# Altium schematic sheet MPU_12x12.SchDoc(U_MPU_12x12)
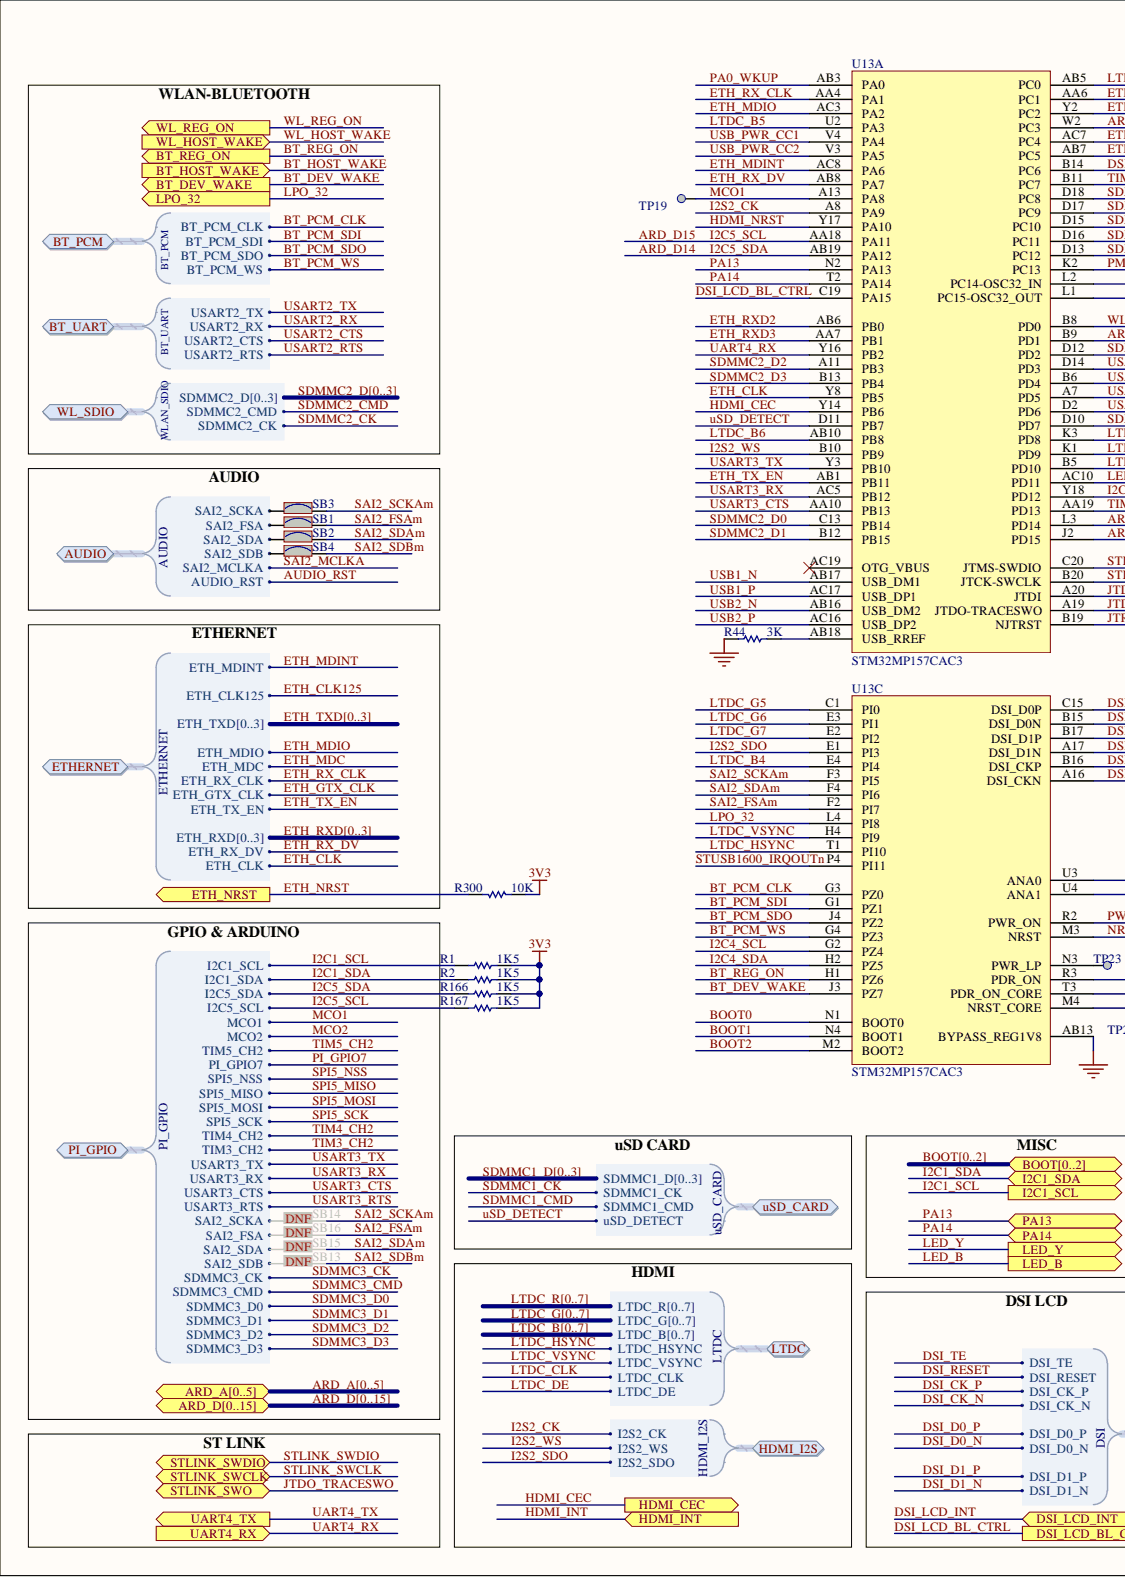
[diagram p0: MPU_12x12.SchDoc, left]
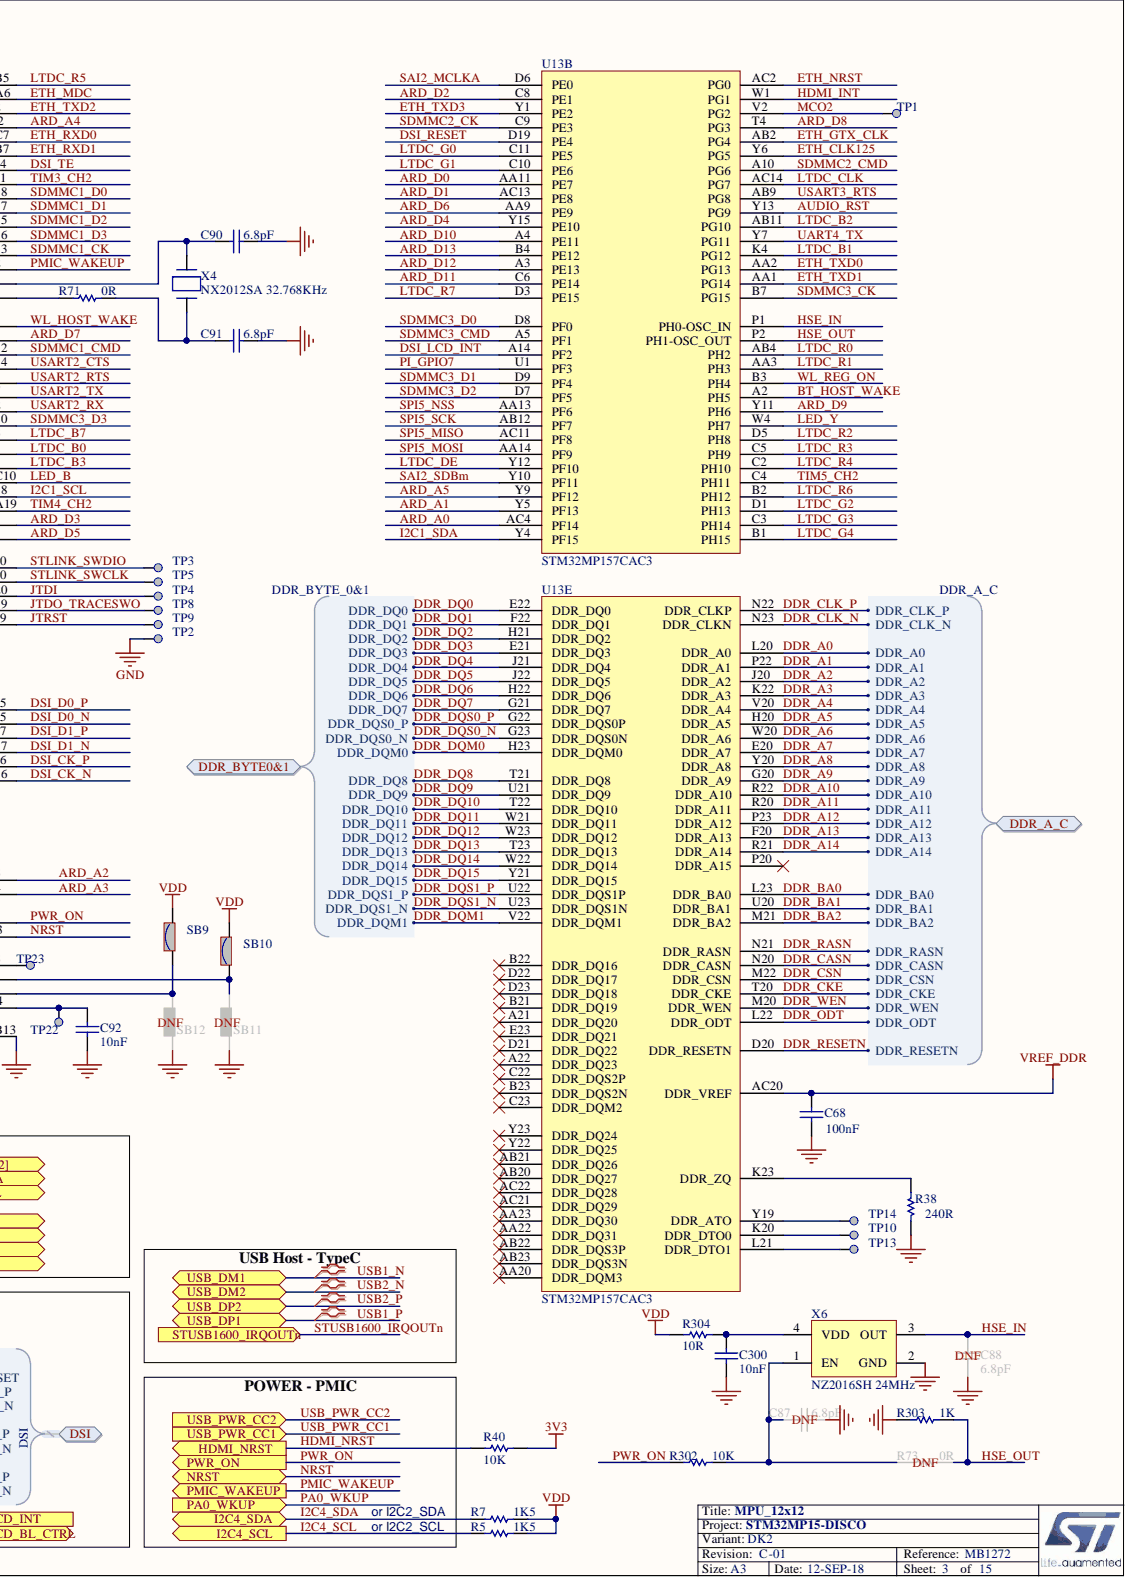
[diagram p1: MPU_12x12.SchDoc, right]

## Parts
C68  value 100nF  [C0402]
C87  value 6.8pF  [C0402]  (hidden comment: Capacitor)
C88  value 6.8pF  [C0402]  (hidden comment: Capacitor)
C90  value 6.8pF  [C0402]  (hidden comment: Capacitor)
C91  value 6.8pF  [C0402]  (hidden comment: Capacitor)
C92  value 10nF  [C0402]  (hidden comment: Capacitor)
C300  value 10nF  [C0402]  (hidden comment: Capacitor)
R1  value 1K5  [R0402]
R2  value 1K5  [R0402]
R5  value 1K5  [R0402]
R7  value 1K5  [R0402]
R38  value 240R  [R0402]
R40  value 10K  [R0402]  (hidden comment: Resistor)
R44  value 3K  [R0402]
R71  value 0R  [R0402]
R73  value 0R  [R0402]
R166  value 1K5  [R0402]
R167  value 1K5  [R0402]
R300  value 10K  [R0402]  (hidden comment: Resistor)
R302  value 10K  [R0402]  (hidden comment: Resistor)
R303  value 1K  [R0402]
R304  value 10R  [R0402]  (hidden comment: Resistor)
SB1  (no value)  [SOLDER-BRIDGE_R0603-0R]
SB2  (no value)  [SOLDER-BRIDGE_R0603-0R]
SB3  (no value)  [SOLDER-BRIDGE_R0603-0R]
SB4  (no value)  [SOLDER-BRIDGE_R0603-0R]
SB9  (no value)  [SOLDER-BRIDGE_R0603-0R]
SB10  (no value)  [SOLDER-BRIDGE_R0603-0R]
SB11  (no value)  [SOLDER-BRIDGE_R0603-0R]
SB12  (no value)  [SOLDER-BRIDGE_R0603-0R]
SB13  (no value)  [SOLDER-BRIDGE_R0603-0R]
SB14  (no value)  [SOLDER-BRIDGE_R0603-0R]
SB15  (no value)  [SOLDER-BRIDGE_R0603-0R]
SB16  (no value)  [SOLDER-BRIDGE_R0603-0R]
TP1  Test Point  [TP_SMD_1MM]  (value not drawn)  (no BOM)
TP2  Test Point  [TP_SMD_1MM]  (value not drawn)  (no BOM)
TP3  Test Point  [TP_SMD_1MM]  (value not drawn)  (no BOM)
TP4  Test Point  [TP_SMD_1MM]  (value not drawn)  (no BOM)
TP5  Test Point  [TP_SMD_1MM]  (value not drawn)  (no BOM)
TP8  Test Point  [TP_SMD_1MM]  (value not drawn)  (no BOM)
TP9  Test Point  [TP_SMD_1MM]  (value not drawn)  (no BOM)
TP10  (no value)  [TP_SMD_0-6MM]
TP13  (no value)  [TP_SMD_0-6MM]
TP14  (no value)  [TP_SMD_0-6MM]
TP19  Test Point  [TP_SMD_1MM]  (value not drawn)  (no BOM)
TP22  Test Point  [TP_SMD_1MM]  (value not drawn)  (no BOM)
TP23  Test Point  [TP_SMD_1MM]  (value not drawn)  (no BOM)
U13  STM32MP157CAC3  [ICC_TFBGA361_12X12_STM32MP157CAC3_P050-065]  (parts A,B,C,E)
U13  STM32MP157AAC3  [ICC_TFBGA361_12X12_STM32MP157CAC3_P050-065]  (value not drawn)  (part A)
U13  STM32MP157AAC3  [ICC_TFBGA361_12X12_STM32MP157CAC3_P050-065]  (value not drawn)  (part B)
U13  STM32MP157AAC3  [ICC_TFBGA361_12X12_STM32MP157CAC3_P050-065]  (value not drawn)  (part C)
U13  STM32MP157AAC3  [ICC_TFBGA361_12X12_STM32MP157CAC3_P050-065]  (value not drawn)  (part E)
X4  value NX2012SA 32.768KHz  Package: NX2012SA  [CRY_NX2012SA_2P]  (hidden comment: XTAL_2_PINS)
X6  value NZ2016SH 24MHz  [CRY_NZ2016SH_4P]  (hidden comment: OSCILLATOR_4_PINS)

## Ports
ARD_A0, ARD_A1, ARD_A2, ARD_A3, ARD_A4, ARD_A5, ARD_A[0..5], ARD_D0, ARD_D1, ARD_D2, ARD_D3, ARD_D4, ARD_D5, ARD_D6, ARD_D7, ARD_D8, ARD_D9, ARD_D10, ARD_D11, ARD_D12, ARD_D13, ARD_D14, ARD_D15, ARD_D[0..15], AUDIO, AUDIO.AUDIO_RST, AUDIO.SAI2_FSA, AUDIO.SAI2_MCLKA, AUDIO.SAI2_SCKA, AUDIO.SAI2_SDA, AUDIO.SAI2_SDB, BOOT0, BOOT1, BOOT2, BOOT[0..2], BT_DEV_WAKE, BT_HOST_WAKE, BT_PCM, BT_PCM.BT_PCM_CLK, BT_PCM.BT_PCM_SDI, BT_PCM.BT_PCM_SDO, BT_PCM.BT_PCM_WS, BT_REG_ON, BT_UART, BT_UART.USART2_CTS, BT_UART.USART2_RTS, BT_UART.USART2_RX, BT_UART.USART2_TX, DDR_A_C, DDR_A_C.DDR_A0, DDR_A_C.DDR_A1, DDR_A_C.DDR_A2, DDR_A_C.DDR_A3, DDR_A_C.DDR_A4, DDR_A_C.DDR_A5, DDR_A_C.DDR_A6, DDR_A_C.DDR_A7, DDR_A_C.DDR_A8, DDR_A_C.DDR_A9, DDR_A_C.DDR_A10, DDR_A_C.DDR_A11, DDR_A_C.DDR_A12, DDR_A_C.DDR_A13, DDR_A_C.DDR_A14, DDR_A_C.DDR_BA0, DDR_A_C.DDR_BA1, DDR_A_C.DDR_BA2, DDR_A_C.DDR_CASN, DDR_A_C.DDR_CKE, DDR_A_C.DDR_CLK_N, DDR_A_C.DDR_CLK_P, DDR_A_C.DDR_CSN, DDR_A_C.DDR_ODT, DDR_A_C.DDR_RASN, DDR_A_C.DDR_RESETN, DDR_A_C.DDR_WEN, DDR_BYTE0&1, DDR_BYTE0&1.DDR_DQ0, DDR_BYTE0&1.DDR_DQ1, DDR_BYTE0&1.DDR_DQ2, DDR_BYTE0&1.DDR_DQ3, DDR_BYTE0&1.DDR_DQ4, DDR_BYTE0&1.DDR_DQ5, DDR_BYTE0&1.DDR_DQ6, DDR_BYTE0&1.DDR_DQ7, DDR_BYTE0&1.DDR_DQ8, DDR_BYTE0&1.DDR_DQ9, DDR_BYTE0&1.DDR_DQ10, DDR_BYTE0&1.DDR_DQ11, DDR_BYTE0&1.DDR_DQ12, DDR_BYTE0&1.DDR_DQ13, DDR_BYTE0&1.DDR_DQ14, DDR_BYTE0&1.DDR_DQ15, DDR_BYTE0&1.DDR_DQM0, DDR_BYTE0&1.DDR_DQM1, DDR_BYTE0&1.DDR_DQS0_N, DDR_BYTE0&1.DDR_DQS0_P, DDR_BYTE0&1.DDR_DQS1_N, DDR_BYTE0&1.DDR_DQS1_P, DSI, DSI.DSI_CK_N, DSI.DSI_CK_P, DSI.DSI_D0_N, DSI.DSI_D0_P, DSI.DSI_D1_N, DSI.DSI_D1_P, DSI.DSI_RESET, DSI.DSI_TE, DSI_LCD_BL_CTRL, DSI_LCD_INT, ETH_NRST, ETHERNET, ETHERNET.ETH_CLK, ETHERNET.ETH_CLK125, ETHERNET.ETH_GTX_CLK, ETHERNET.ETH_MDC, ETHERNET.ETH_MDINT, ETHERNET.ETH_MDIO, ETHERNET.ETH_RX_CLK, ETHERNET.ETH_RX_DV, ETHERNET.ETH_RXD0, ETHERNET.ETH_RXD1, ETHERNET.ETH_RXD2, ETHERNET.ETH_RXD3, ETHERNET.ETH_RXD[0..3], ETHERNET.ETH_TX_EN, ETHERNET.ETH_TXD0, ETHERNET.ETH_TXD1, ETHERNET.ETH_TXD2, ETHERNET.ETH_TXD3, ETHERNET.ETH_TXD[0..3], HDMI_CEC, HDMI_I2S, HDMI_I2S.I2S2_CK, HDMI_I2S.I2S2_SDO, HDMI_I2S.I2S2_WS, HDMI_INT, HDMI_NRST, I2C1_SCL, I2C1_SDA, I2C4_SCL, I2C4_SDA, LED_B, LED_Y, LPO_32, LTDC, LTDC.LTDC_B0, LTDC.LTDC_B1, LTDC.LTDC_B2, LTDC.LTDC_B3, LTDC.LTDC_B4, LTDC.LTDC_B5, LTDC.LTDC_B6, LTDC.LTDC_B7, LTDC.LTDC_B[0..7], LTDC.LTDC_CLK, LTDC.LTDC_DE, LTDC.LTDC_G0, LTDC.LTDC_G1, LTDC.LTDC_G2, LTDC.LTDC_G3, LTDC.LTDC_G4, LTDC.LTDC_G5, LTDC.LTDC_G6, LTDC.LTDC_G7, LTDC.LTDC_G[0..7], LTDC.LTDC_HSYNC, LTDC.LTDC_R0, LTDC.LTDC_R1, LTDC.LTDC_R2, LTDC.LTDC_R3, LTDC.LTDC_R4, LTDC.LTDC_R5, LTDC.LTDC_R6, LTDC.LTDC_R7, LTDC.LTDC_R[0..7], LTDC.LTDC_VSYNC, NRST, PA0_WKUP, PA13, PA14, PI_GPIO, PI_GPIO.I2C1_SCL, PI_GPIO.I2C1_SDA, PI_GPIO.I2C5_SCL, PI_GPIO.I2C5_SDA, PI_GPIO.MCO1, PI_GPIO.MCO2, PI_GPIO.PI_GPIO7, PI_GPIO.SAI2_FSA, PI_GPIO.SAI2_SCKA, PI_GPIO.SAI2_SDA, PI_GPIO.SAI2_SDB, PI_GPIO.SDMMC3_CK, PI_GPIO.SDMMC3_CMD, PI_GPIO.SDMMC3_D0, PI_GPIO.SDMMC3_D1, PI_GPIO.SDMMC3_D2, PI_GPIO.SDMMC3_D3, PI_GPIO.SPI5_MISO, PI_GPIO.SPI5_MOSI, PI_GPIO.SPI5_NSS, PI_GPIO.SPI5_SCK, PI_GPIO.TIM3_CH2, PI_GPIO.TIM4_CH2, PI_GPIO.TIM5_CH2, PI_GPIO.USART3_CTS, PI_GPIO.USART3_RTS, PI_GPIO.USART3_RX, PI_GPIO.USART3_TX, PMIC_WAKEUP, PWR_ON, STLINK_SWCLK, STLINK_SWDIO, STLINK_SWO, STUSB1600_IRQOUTn, UART4_RX, UART4_TX, USB_DM1, USB_DM2, USB_DP1, USB_DP2, USB_PWR_CC1, USB_PWR_CC2, uSD_CARD, uSD_CARD.SDMMC1_CK, uSD_CARD.SDMMC1_CMD, USD_CARD.SDMMC1_D0, USD_CARD.SDMMC1_D1, USD_CARD.SDMMC1_D2, USD_CARD.SDMMC1_D3, uSD_CARD.SDMMC1_D[0..3], uSD_CARD.uSD_DETECT, WL_HOST_WAKE, WL_REG_ON, WL_SDIO, WL_SDIO.SDMMC2_CK, WL_SDIO.SDMMC2_CMD, WL_SDIO.SDMMC2_D0, WL_SDIO.SDMMC2_D1, WL_SDIO.SDMMC2_D2, WL_SDIO.SDMMC2_D3, WL_SDIO.SDMMC2_D[0..3]

## Nets
3V3: R1.1 R2.1 R40.1 R166.1 R167.1 R300.1
GND: C68.1 C87.2 C88.1 C90.2 C91.2 C92.1 C300.1 R38.2 R44.1 R303.2 SB11.1 SB12.1 TP2.1 U13.AB13 X6.2(GND)
VDD: R5.1 R7.1 R304.1 SB9.2 SB10.2
VREF_DDR: C68.2 U13.AC20
ARD_A0: U13.AC4 ; port ARD_A[0..5]  [also labelled ARD_A]
ARD_A1: U13.Y5 ; port ARD_A[0..5]  [also labelled ARD_A]
ARD_A2: U13.U3 ; port ARD_A[0..5]  [also labelled ARD_A]
ARD_A3: U13.U4 ; port ARD_A[0..5]  [also labelled ARD_A]
ARD_A4: U13.W2 ; port ARD_A[0..5]  [also labelled ARD_A]
ARD_A5: U13.Y9 ; port ARD_A[0..5]  [also labelled ARD_A]
ARD_D0: U13.AA11 ; port ARD_D[0..15]  [also labelled ARD_D]
ARD_D1: U13.AC13 ; port ARD_D[0..15]  [also labelled ARD_D]
ARD_D2: U13.C8 ; port ARD_D[0..15]  [also labelled ARD_D]
ARD_D3: U13.L3 ; port ARD_D[0..15]  [also labelled ARD_D]
ARD_D4: U13.Y15 ; port ARD_D[0..15]  [also labelled ARD_D]
ARD_D5: U13.J2 ; port ARD_D[0..15]  [also labelled ARD_D]
ARD_D6: U13.AA9 ; port ARD_D[0..15]  [also labelled ARD_D]
ARD_D7: U13.B9 ; port ARD_D[0..15]  [also labelled ARD_D]
ARD_D8: U13.T4 ; port ARD_D[0..15]  [also labelled ARD_D]
ARD_D9: U13.Y11 ; port ARD_D[0..15]  [also labelled ARD_D]
ARD_D10: U13.A4 ; port ARD_D[0..15]  [also labelled ARD_D]
ARD_D11: U13.C6 ; port ARD_D[0..15]  [also labelled ARD_D]
ARD_D12: U13.A3 ; port ARD_D[0..15]  [also labelled ARD_D]
ARD_D13: U13.B4 ; port ARD_D[0..15]  [also labelled ARD_D]
ARD_D14: R166.2 U13.AB19 ; port ARD_D[0..15], port PI_GPIO  [also labelled ARD_D]
ARD_D15: R167.2 U13.AA18 ; port ARD_D[0..15], port PI_GPIO  [also labelled ARD_D]
AUDIO_RST: U13.Y13 ; port AUDIO
BOOT0: U13.N1 ; port BOOT[0..2]  [also labelled BOOT]
BOOT1: U13.N4 ; port BOOT[0..2]  [also labelled BOOT]
BOOT2: U13.M2 ; port BOOT[0..2]  [also labelled BOOT]
BT_DEV_WAKE: U13.J3 ; port BT_DEV_WAKE
BT_HOST_WAKE: U13.A2 ; port BT_HOST_WAKE
BT_PCM_CLK: U13.G3 ; port BT_PCM
BT_PCM_SDI: U13.G1 ; port BT_PCM
BT_PCM_SDO: U13.J4 ; port BT_PCM
BT_PCM_WS: U13.G4 ; port BT_PCM
BT_REG_ON: U13.H1 ; port BT_REG_ON
DDR_A0: U13.L20 ; port DDR_A_C
DDR_A1: U13.P22 ; port DDR_A_C
DDR_A2: U13.J20 ; port DDR_A_C
DDR_A3: U13.K22 ; port DDR_A_C
DDR_A4: U13.V20 ; port DDR_A_C
DDR_A5: U13.H20 ; port DDR_A_C
DDR_A6: U13.W20 ; port DDR_A_C
DDR_A7: U13.E20 ; port DDR_A_C
DDR_A8: U13.Y20 ; port DDR_A_C
DDR_A9: U13.G20 ; port DDR_A_C
DDR_A10: U13.R22 ; port DDR_A_C
DDR_A11: U13.R20 ; port DDR_A_C
DDR_A12: U13.P23 ; port DDR_A_C
DDR_A13: U13.F20 ; port DDR_A_C
DDR_A14: U13.R21 ; port DDR_A_C
DDR_BA0: U13.L23 ; port DDR_A_C
DDR_BA1: U13.U20 ; port DDR_A_C
DDR_BA2: U13.M21 ; port DDR_A_C
DDR_CASN: U13.N20 ; port DDR_A_C
DDR_CKE: U13.T20 ; port DDR_A_C
DDR_CLK_N: U13.N23 ; port DDR_A_C
DDR_CLK_P: U13.N22 ; port DDR_A_C
DDR_CSN: U13.M22 ; port DDR_A_C
DDR_DQ0: U13.E22 ; port DDR_BYTE0&1
DDR_DQ1: U13.F22 ; port DDR_BYTE0&1
DDR_DQ2: U13.H21 ; port DDR_BYTE0&1
DDR_DQ3: U13.E21 ; port DDR_BYTE0&1
DDR_DQ4: U13.J21 ; port DDR_BYTE0&1
DDR_DQ5: U13.J22 ; port DDR_BYTE0&1
DDR_DQ6: U13.H22 ; port DDR_BYTE0&1
DDR_DQ7: U13.G21 ; port DDR_BYTE0&1
DDR_DQ8: U13.T21 ; port DDR_BYTE0&1
DDR_DQ9: U13.U21 ; port DDR_BYTE0&1
DDR_DQ10: U13.T22 ; port DDR_BYTE0&1
DDR_DQ11: U13.W21 ; port DDR_BYTE0&1
DDR_DQ12: U13.W23 ; port DDR_BYTE0&1
DDR_DQ13: U13.T23 ; port DDR_BYTE0&1
DDR_DQ14: U13.W22 ; port DDR_BYTE0&1
DDR_DQ15: U13.Y21 ; port DDR_BYTE0&1
DDR_DQM0: U13.H23 ; port DDR_BYTE0&1
DDR_DQM1: U13.V22 ; port DDR_BYTE0&1
DDR_DQS0_N: U13.G23 ; port DDR_BYTE0&1
DDR_DQS0_P: U13.G22 ; port DDR_BYTE0&1
DDR_DQS1_N: U13.U23 ; port DDR_BYTE0&1
DDR_DQS1_P: U13.U22 ; port DDR_BYTE0&1
DDR_ODT: U13.L22 ; port DDR_A_C
DDR_RASN: U13.N21 ; port DDR_A_C
DDR_RESETN: U13.D20 ; port DDR_A_C
DDR_WEN: U13.M20 ; port DDR_A_C
DSI_CK_N: U13.A16 ; port DSI
DSI_CK_P: U13.B16 ; port DSI
DSI_D0_N: U13.B15 ; port DSI
DSI_D0_P: U13.C15 ; port DSI
DSI_D1_N: U13.A17 ; port DSI
DSI_D1_P: U13.B17 ; port DSI
DSI_LCD_BL_CTRL: U13.C19 ; port DSI_LCD_BL_CTRL
DSI_LCD_INT: U13.A14 ; port DSI_LCD_INT
DSI_RESET: U13.D19 ; port DSI
DSI_TE: U13.B14 ; port DSI
ETH_CLK: U13.Y8 ; port ETHERNET
ETH_CLK125: U13.Y6 ; port ETHERNET
ETH_GTX_CLK: U13.AB2 ; port ETHERNET
ETH_MDC: U13.AA6 ; port ETHERNET
ETH_MDINT: U13.AC8 ; port ETHERNET
ETH_MDIO: U13.AC3 ; port ETHERNET
ETH_NRST: R300.2 U13.AC2 ; port ETH_NRST
ETH_RX_CLK: U13.AA4 ; port ETHERNET
ETH_RX_DV: U13.AB8 ; port ETHERNET
ETH_RXD0: U13.AC7 ; port ETHERNET  [also labelled ETH_RXD]
ETH_RXD1: U13.AB7 ; port ETHERNET  [also labelled ETH_RXD]
ETH_RXD2: U13.AB6 ; port ETHERNET  [also labelled ETH_RXD]
ETH_RXD3: U13.AA7 ; port ETHERNET  [also labelled ETH_RXD]
ETH_TX_EN: U13.AB1 ; port ETHERNET
ETH_TXD0: U13.AA2 ; port ETHERNET  [also labelled ETH_TXD]
ETH_TXD1: U13.AA1 ; port ETHERNET  [also labelled ETH_TXD]
ETH_TXD2: U13.Y2 ; port ETHERNET  [also labelled ETH_TXD]
ETH_TXD3: U13.Y1 ; port ETHERNET  [also labelled ETH_TXD]
HDMI_CEC: U13.Y14 ; port HDMI_CEC
HDMI_INT: U13.W1 ; port HDMI_INT
HDMI_NRST: R40.2 U13.Y17 ; port HDMI_NRST
HSE_IN: C88.2 U13.P1 X6.3(OUT)
HSE_OUT: R73.2 R303.1 U13.P2
I2C1_SCL: R1.2 U13.Y18 ; port I2C1_SCL, port PI_GPIO
I2C1_SDA: R2.2 U13.Y4 ; port I2C1_SDA, port PI_GPIO
I2C4_SCL: R5.2 U13.G2 ; port I2C4_SCL
I2C4_SDA: R7.2 U13.H2 ; port I2C4_SDA
I2S2_CK: U13.A8 ; port HDMI_I2S
I2S2_SDO: U13.E1 ; port HDMI_I2S
I2S2_WS: U13.B10 ; port HDMI_I2S
JTDI: TP4.1 U13.A20
JTDO_TRACESWO: TP8.1 U13.A19 ; port STLINK_SWO
JTRST: TP9.1 U13.B19
LED_B: U13.AC10 ; port LED_B
LED_Y: U13.W4 ; port LED_Y
LPO_32: U13.L4 ; port LPO_32
LTDC_B0: U13.K1 ; port LTDC  [also labelled LTDC_B]
LTDC_B1: U13.K4 ; port LTDC  [also labelled LTDC_B]
LTDC_B2: U13.AB11 ; port LTDC  [also labelled LTDC_B]
LTDC_B3: U13.B5 ; port LTDC  [also labelled LTDC_B]
LTDC_B4: U13.E4 ; port LTDC  [also labelled LTDC_B]
LTDC_B5: U13.U2 ; port LTDC  [also labelled LTDC_B]
LTDC_B6: U13.AB10 ; port LTDC  [also labelled LTDC_B]
LTDC_B7: U13.K3 ; port LTDC  [also labelled LTDC_B]
LTDC_CLK: U13.AC14 ; port LTDC
LTDC_DE: U13.Y12 ; port LTDC
LTDC_G0: U13.C11 ; port LTDC  [also labelled LTDC_G]
LTDC_G1: U13.C10 ; port LTDC  [also labelled LTDC_G]
LTDC_G2: U13.D1 ; port LTDC  [also labelled LTDC_G]
LTDC_G3: U13.C3 ; port LTDC  [also labelled LTDC_G]
LTDC_G4: U13.B1 ; port LTDC  [also labelled LTDC_G]
LTDC_G5: U13.C1 ; port LTDC  [also labelled LTDC_G]
LTDC_G6: U13.E3 ; port LTDC  [also labelled LTDC_G]
LTDC_G7: U13.E2 ; port LTDC  [also labelled LTDC_G]
LTDC_HSYNC: U13.T1 ; port LTDC
LTDC_R0: U13.AB4 ; port LTDC  [also labelled LTDC_R]
LTDC_R1: U13.AA3 ; port LTDC  [also labelled LTDC_R]
LTDC_R2: U13.D5 ; port LTDC  [also labelled LTDC_R]
LTDC_R3: U13.C5 ; port LTDC  [also labelled LTDC_R]
LTDC_R4: U13.C2 ; port LTDC  [also labelled LTDC_R]
LTDC_R5: U13.AB5 ; port LTDC  [also labelled LTDC_R]
LTDC_R6: U13.B2 ; port LTDC  [also labelled LTDC_R]
LTDC_R7: U13.D3 ; port LTDC  [also labelled LTDC_R]
LTDC_VSYNC: U13.H4 ; port LTDC
MCO1: TP19.1 U13.A13 ; port PI_GPIO
MCO2: TP1.1 U13.V2 ; port PI_GPIO
NRST: U13.M3 ; port NRST
PA0_WKUP: U13.AB3 ; port PA0_WKUP
PA13: U13.N2 ; port PA13
PA14: U13.T2 ; port PA14
PI_GPIO7: U13.U1 ; port PI_GPIO
PMIC_WAKEUP: U13.K2 ; port PMIC_WAKEUP
PWR_ON: R302.2 U13.R2 ; port PWR_ON
SAI2_FSAm: SB1.2 SB16.2 U13.F2
SAI2_MCLKA: U13.D6 ; port AUDIO
SAI2_SCKAm: SB3.2 SB14.2 U13.F3
SAI2_SDAm: SB2.2 SB15.2 U13.F4
SAI2_SDBm: SB4.2 SB13.2 U13.Y10
SDMMC1_CK: U13.D13 ; port uSD_CARD
SDMMC1_CMD: U13.D12 ; port uSD_CARD
SDMMC1_D0: U13.D18 ; port uSD_CARD  [also labelled SDMMC1_D]
SDMMC1_D1: U13.D17 ; port uSD_CARD  [also labelled SDMMC1_D]
SDMMC1_D2: U13.D15 ; port uSD_CARD  [also labelled SDMMC1_D]
SDMMC1_D3: U13.D16 ; port uSD_CARD  [also labelled SDMMC1_D]
SDMMC2_CK: U13.C9 ; port WL_SDIO
SDMMC2_CMD: U13.A10 ; port WL_SDIO
SDMMC2_D0: U13.C13 ; port WL_SDIO  [also labelled SDMMC2_D]
SDMMC2_D1: U13.B12 ; port WL_SDIO  [also labelled SDMMC2_D]
SDMMC2_D2: U13.A11 ; port WL_SDIO  [also labelled SDMMC2_D]
SDMMC2_D3: U13.B13 ; port WL_SDIO  [also labelled SDMMC2_D]
SDMMC3_CK: U13.B7 ; port PI_GPIO
SDMMC3_CMD: U13.A5 ; port PI_GPIO
SDMMC3_D0: U13.D8 ; port PI_GPIO
SDMMC3_D1: U13.D9 ; port PI_GPIO
SDMMC3_D2: U13.D7 ; port PI_GPIO
SDMMC3_D3: U13.D10 ; port PI_GPIO
SPI5_MISO: U13.AC11 ; port PI_GPIO
SPI5_MOSI: U13.AA14 ; port PI_GPIO
SPI5_NSS: U13.AA13 ; port PI_GPIO
SPI5_SCK: U13.AB12 ; port PI_GPIO
STLINK_SWCLK: TP5.1 U13.B20 ; port STLINK_SWCLK
STLINK_SWDIO: TP3.1 U13.C20 ; port STLINK_SWDIO
STUSB1600_IRQOUTn: U13.P4 ; port STUSB1600_IRQOUTn
TIM3_CH2: U13.B11 ; port PI_GPIO
TIM4_CH2: U13.AA19 ; port PI_GPIO
TIM5_CH2: U13.C4 ; port PI_GPIO
UART4_RX: U13.Y16 ; port UART4_RX
UART4_TX: U13.Y7 ; port UART4_TX
USART2_CTS: U13.D14 ; port BT_UART
USART2_RTS: U13.B6 ; port BT_UART
USART2_RX: U13.D2 ; port BT_UART
USART2_TX: U13.A7 ; port BT_UART
USART3_CTS: U13.AA10 ; port PI_GPIO
USART3_RTS: U13.AB9 ; port PI_GPIO
USART3_RX: U13.AC5 ; port PI_GPIO
USART3_TX: U13.Y3 ; port PI_GPIO
USB1_N: U13.AB17 ; port USB_DM1
USB1_P: U13.AC17 ; port USB_DP1
USB2_N: U13.AB16 ; port USB_DM2
USB2_P: U13.AC16 ; port USB_DP2
USB_PWR_CC1: U13.V4 ; port USB_PWR_CC1
USB_PWR_CC2: U13.V3 ; port USB_PWR_CC2
uSD_DETECT: U13.D11 ; port uSD_CARD
WL_HOST_WAKE: U13.B8 ; port WL_HOST_WAKE
WL_REG_ON: U13.B3 ; port WL_REG_ON
NetC87_1: C87.1 R73.1 R302.1 X6.1(EN)
NetC90_1: C90.1 U13.L2 X4.2
NetC91_1: C91.1 R71.2 X4.1
NetC92_2: C92.2 TP22.1 U13.M4
NetC300_2: C300.2 R304.2 X6.4(VDD)
NetR38_1: R38.1 U13.K23
NetR44_2: R44.2 U13.AB18
NetR71_1: R71.1 U13.L1
NetSB1_1: SB1.1 ; port AUDIO
NetSB2_1: SB2.1 ; port AUDIO
NetSB3_1: SB3.1 ; port AUDIO
NetSB4_1: SB4.1 ; port AUDIO
NetSB9_1: SB9.1 SB12.2 U13.T3
NetSB10_1: SB10.1 SB11.2 U13.R3
NetSB13_1: SB13.1 ; port PI_GPIO
NetSB14_1: SB14.1 ; port PI_GPIO
NetSB15_1: SB15.1 ; port PI_GPIO
NetSB16_1: SB16.1 ; port PI_GPIO
NetTP10_1: TP10.1 U13.K20
NetTP13_1: TP13.1 U13.L21
NetTP14_1: TP14.1 U13.Y19
NetTP23_1: TP23.1 U13.N3

Not connected: U13 A21 A22 AA20 AA22 AA23 AB20 AB21 AB22 AB23 AC19 AC21 AC22 B21 B22 B23 C22 C23 D21 D22 D23 E23 P20 Y22 Y23

## Library data of the parts
C68 = MCD-00006: Capacitor,100nF,16V,X7R,10%,0402; Manufacturer_Part_Number: CC0402KRX7R7BB104; Manufacturer: YAGEO; Alternate_Manufacturer_Part_Number: GCM155R71C104KA55D; Alternate_Manufacturer: MURATA; Provided_By: SUBCO; Initial_Board_Reference: mb1274; Database Table Name: MCD_PARTS$; Status: Released; Part_Type: Capacitor; Package: C0402; Library Name: MCD_PARTS_database.DbLib; Internal_Part_Number: MCD-00006; ECCN_US: n/a; ECCN_EU: n/a; ComponentLink1URL: http://tunmcdsvn.tun.st.com/Component_Datasheet_Library/Trunk/Capacitors/Yageo_Multilayer_Capacitor_X7R_series_100pF_to_22uF; ComponentLink1Description: Datasheet; Component Kind: Standard
C87 C88 = MCD-00674: Capacitor, MLCC, 6.8pF, 50V, NPO, +-0.25pF, 0402, T=0.5mm; Manufacturer_Part_Number: CC0402CRNPO9BN6R8; Manufacturer: YAGEO; Provided_By: SUBCO; Initial_Board_Reference: MB1319; Database Table Name: MCD_PARTS$; Status: Released; Part_Type: Capacitor; Package: C0402; Library Name: MCD_PARTS_database.DbLib; Internal_Part_Number: MCD-00674; ECCN_US: n/a; ECCN_EU: n/a; ComponentLink1URL: http://tunmcdsvn.tun.st.com/Component_Datasheet_Library/Trunk/Capacitors/Yageo_Capacitor_NP0_16V-to-50V; ComponentLink1Description: Datasheet; Component Kind: Standard
C90 C91 = MCD-00674: Capacitor, MLCC, 6.8pF, 50V, NPO, +-0.25pF, 0402, T=0.5mm; Manufacturer_Part_Number: CC0402CRNPO9BN6R8; Manufacturer: YAGEO; Provided_By: SUBCO; Path: ws/MCD_ApplicationBoards/Lists/MCDPARTSdatabase; Item Type: Item; Initial_Board_Reference: MB1319; Database Table Name: MCD_PARTS$; Status: Released; Part_Type: Capacitor; Package: C0402; Library Name: MCD_PARTS_database.DbLib; Internal_Part_Number: MCD-00674; ComponentLink1URL: http://tunmcdsvn.tun.st.com/Component_Datasheet_Library/Trunk/Capacitors/Yageo_Capacitor_NP0_16V-to-50V; ComponentLink1Description: Datasheet; Component Kind: Standard
C92 C300 = MCD-00011: Capacitor, MLCC, 10nF, 16V, X7R, 10%, 0402, T=0.5mm; Manufacturer_Part_Number: CC0402KRX7R7BB103; Manufacturer: YAGEO; Provided_By: SUBCO; Initial_Board_Reference: MB1274; Database Table Name: MCD_PARTS$; Status: Released; Part_Type: Capacitor; Package: C0402; Library Name: MCD_PARTS_database.DbLib; Internal_Part_Number: MCD-00011; ECCN_US: n/a; ECCN_EU: n/a; ComponentLink1URL: http://tunmcdsvn.tun.st.com/Component_Datasheet_Library/Trunk/Capacitors/Yageo_Multilayer_Capacitor_X7R_series_100pF_to_22uF; ComponentLink1Description: Datasheet; Component Kind: Standard
R1 R2 R5 R7 R166 R167 = MCD-00068: Resistor,1K5,0402,1%,1/16W; Manufacturer_Part_Number: RC0402FR-071K5L; Manufacturer: YAGEO; Provided_By: SUBCO; Initial_Board_Reference: mb1274; Database Table Name: MCD_PARTS$; Status: Released; Part_Type: Resistor; Package: R0402; Library Name: MCD_PARTS_database.DbLib; Internal_Part_Number: MCD-00068; ECCN_US: n/a; ECCN_EU: n/a; ComponentLink1URL: http://tunmcdsvn.tun.st.com/Component_Datasheet_Library/Trunk/Resistors/Yageo_Resistor_RC0402-series; ComponentLink1Description: Datasheet; Component Kind: Standard
R38 = MCD-00120: Resistor,240R,0402,1%,1/16W; Manufacturer_Part_Number: RC0402FR-07240RL; Manufacturer: YAGEO; Provided_By: SUBCO; Initial_Board_Reference: mb1262; Database Table Name: MCD_PARTS$; Status: Released; Part_Type: Resistor; Package: R0402; Library Name: MCD_PARTS_database.DbLib; Internal_Part_Number: MCD-00120; ECCN_US: n/a; ECCN_EU: n/a; ComponentLink1URL: http://tunmcdsvn.tun.st.com/Component_Datasheet_Library/Trunk/Resistors/Yageo_Resistor_RC0402-series; ComponentLink1Description: Datasheet; Component Kind: Standard
R40 R300 R302 = MCD-00053: Resistor,10K,0402,1%,1/16W; Manufacturer_Part_Number: RC0402FR-0710KL; Manufacturer: YAGEO; Provided_By: SUBCO; Initial_Board_Reference: MB1274; Database Table Name: MCD_PARTS$; Status: Released; Part_Type: Resistor; Package: R0402; Library Name: MCD_PARTS_database.DbLib; Internal_Part_Number: MCD-00053; ECCN_US: n/a; ECCN_EU: n/a; ComponentLink1URL: http://tunmcdsvn.tun.st.com/Component_Datasheet_Library/Trunk/Resistors/Yageo_Resistor_RC0402-series; ComponentLink1Description: Datasheet; Component Kind: Standard
R44 = MCD-00185: Resistor,3K,0402,1%,1/16W; Manufacturer_Part_Number: RC0402FR-073KL; Manufacturer: YAGEO; Provided_By: SUBCO; Database Table Name: MCD_PARTS$; Status: Released; Part_Type: Resistor; Package: R0402; Library Name: MCD_PARTS_database.DbLib; Internal_Part_Number: MCD-00185; ECCN_US: n/a; ECCN_EU: n/a; ComponentLink1URL: http://tunmcdsvn.tun.st.com/Component_Datasheet_Library/Trunk/Resistors/Yageo_Resistor_RC0402-series; ComponentLink1Description: Datasheet; Component Kind: Standard
R71 R73 = MCD-00052: Resistor,0R,0402,5%,1/16W; Manufacturer_Part_Number: RC0402JR-070RL; Manufacturer: YAGEO; Provided_By: SUBCO; Initial_Board_Reference: mb1274; Database Table Name: MCD_PARTS$; Status: Released; Part_Type: Resistor; Package: R0402; Library Name: MCD_PARTS_database.DbLib; Internal_Part_Number: MCD-00052; ECCN_US: n/a; ECCN_EU: n/a; ComponentLink1URL: http://tunmcdsvn.tun.st.com/Component_Datasheet_Library/Trunk/Resistors/Yageo_Resistor_RC0402-series; ComponentLink1Description: Datasheet; Component Kind: Standard
R303 = MCD-00061: Resistor,1K,0402,1%,1/16W; Manufacturer_Part_Number: RC0402FR-071KL; Manufacturer: YAGEO; Provided_By: SUBCO; Initial_Board_Reference: mb1274; Database Table Name: MCD_PARTS$; Status: Released; Part_Type: Resistor; Package: R0402; Library Name: MCD_PARTS_database.DbLib; Internal_Part_Number: MCD-00061; ECCN_US: n/a; ECCN_EU: n/a; ComponentLink1URL: http://tunmcdsvn.tun.st.com/Component_Datasheet_Library/Trunk/Resistors/Yageo_Resistor_RC0402-series; ComponentLink1Description: Datasheet; Component Kind: Standard
R304 = MCD-00358: Resistor,10R,0402,1%,1/16W; Manufacturer_Part_Number: RC0402FR-0710RL; Manufacturer: YAGEO; Provided_By: SUBCO; Database Table Name: MCD_PARTS$; Status: Released; Part_Type: Resistor; Package: R0402; Library Name: MCD_PARTS_database.DbLib; Internal_Part_Number: MCD-00358; ComponentLink1URL: http://tunmcdsvn.tun.st.com/Component_Datasheet_Library/Trunk/Resistors/Yageo_Resistor_RC0402-series; ComponentLink1Description: Datasheet; Component Kind: Standard
SB1-SB4 SB9 SB10 = MCD-00071: Solder Bridge ON; Part_Type: Mechanical; Manufacturer_Part_Number: RC0603JR-070RL; Manufacturer: YAGEO; Provided_By: SUBCO; Initial_Board_Reference: mb1274; Database Table Name: MCD_PARTS$; Status: Released; Package: SB0603; Library Name: MCD_PARTS_database.DbLib; Internal_Part_Number: MCD-00071; ECCN_US: n/a; ECCN_EU: n/a; ComponentLink1URL: http://tunmcdsvn.tun.st.com/Component_Datasheet_Library/Trunk/Resistors/Yageo_Resistor_RC0603-series; ComponentLink1Description: Datasheet; Component Kind: Standard
SB11-SB16 = MCD-00072: Solder Bridge OFF; Part_Type: Mechanical; Manufacturer_Part_Number: RC0603JR-070RL; Manufacturer: YAGEO; Provided_By: SUBCO; Initial_Board_Reference: mb1274; Database Table Name: MCD_PARTS$; Status: Released; Package: SB0603; Library Name: MCD_PARTS_database.DbLib; Internal_Part_Number: MCD-00072; ECCN_US: n/a; ECCN_EU: n/a; ComponentLink1URL: http://tunmcdsvn.tun.st.com/Component_Datasheet_Library/Trunk/Resistors/Yageo_Resistor_RC0603-series; ComponentLink1Description: Datasheet; Component Kind: Standard
TP1-TP5 TP8 TP9 TP19 TP22 TP23 = MCD-00074: Test Point 1mm; Part_Type: Mechanical; Initial_Board_Reference: MB1274; Database Table Name: MCD_PARTS$; Status: Released; Provided_By: n/a; Manufacturer_Part_Number: n/a; Manufacturer: n/a; Library Name: MCD_PARTS_database.DbLib; Internal_Part_Number: MCD-00074; ECCN_US: n/a; ECCN_EU: n/a; ComponentLink1URL: http://na; ComponentLink1Description: Datasheet
TP10 TP13 TP14 = MCD-00631: Test Point 0.6mm; Part_Type: Mechanical; Initial_Board_Reference: mb1264; Database Table Name: MCD_PARTS$; Status: Released; Provided_By: n/a; Library Name: MCD_PARTS_database.DbLib; Internal_Part_Number: MCD-00631; ECCN_US: n/a; ECCN_EU: n/a; ComponentLink1Description: Datasheet; Component Kind: Standard
U13 = MCD-00677: MPU TFBGA12x12 secure boot+crypto; Part_Type: IC; Manufacturer: STMICROELECTRONICS; BMS_Part_Number: 9175503; Provided_By: SUBCO with ST control; Database Table Name: MCD_PARTS$; Value: STM32MP157CAC3; Status: Footprint available; Manufacturer_Part_Number: STM32MP157CAC3; Library Name: MCD_PARTS_database.DbLib; Internal_Part_Number: MCD-00677; ComponentLink1URL: http://tunmcdsvn.tun.st.com/Component_Datasheet_Library/Trunk/IC/STM_MPU_12x12_POA_DM00342580_POA12x12; ComponentLink1Description: Datasheet; Component Kind: Standard
U13 U13 U13 U13 = MCD-01709: MPU TFBGA12x12 without crypto; Part_Type: IC; Manufacturer: STMICROELECTRONICS; Provided_By: SUBCO with ST control; Database Table Name: MCD_PARTS$; Value: STM32MP157AAC3; Status: Footprint available; Package: TFBGA12x12; Manufacturer_Part_Number: STM32MP157AAC3; Library Name: MCD_PARTS_database.DbLib; Internal_Part_Number: MCD-01709; ComponentLink1URL: http://tunmcdsvn.tun.st.com/Component_Datasheet_Library/Trunk/IC/STM_MPU_12x12_POA_DM00342580_POA12x12; ComponentLink1Description: Datasheet; Component Kind: Standard
X4 = MCD-01479: Crystal 32.768kHz, 6pF, -+20PPM, R=80K, SMD; Part_Type: Crystal_Osc; Manufacturer_Part_Number: NX2012SA 32.768KHz EXS00A-MU00527; Manufacturer: NDK; Value: NX2012SA 32.768KHz; Provided_By: SUBCO; Path: ws/MCD_ApplicationBoards/Lists/MCDPARTSdatabase; Item Type: Item; Initial_Board_Reference: MB1373; Database Table Name: MCD_PARTS$; Status: Checked; Library Name: MCD_PARTS_database.DbLib; Internal_Part_Number: MCD-01479; ComponentLink1URL: http://tunmcdsvn.tun.st.com/Component_Datasheet_Library/Trunk/Crystal_Osc/NDK_NX2012SA 32.768KHz EXS00A-MU00527; ComponentLink1Description: Datasheet; Component Kind: Standard
X6 = MCD-01627: Oscillator 24Mhz, +-50ppm, Vcc:3.3V typ (1.62V min), square wave: [0.1Vcc;0.9Vcc], Enable pin; Part_Type: Crystal_Osc; Manufacturer_Part_Number: NZ2016SH 24MHz END4869F; Manufacturer: NDK; Provided_By: SUBCO; Database Table Name: MCD_PARTS$; Status: Footprint available; Package: 2.0x1.6x0.7mm; Library Name: MCD_PARTS_database.DbLib; Internal_Part_Number: MCD-01627; ComponentLink1URL: http://tunmcdsvn.tun.st.com/Component_Datasheet_Library/Trunk/Crystal_Osc/NDK_NZ2016SH 24MHz END4869F; ComponentLink1Description: Datasheet; Component Kind: Standard
